# Altium schematic sheet Sheet3.SchDoc
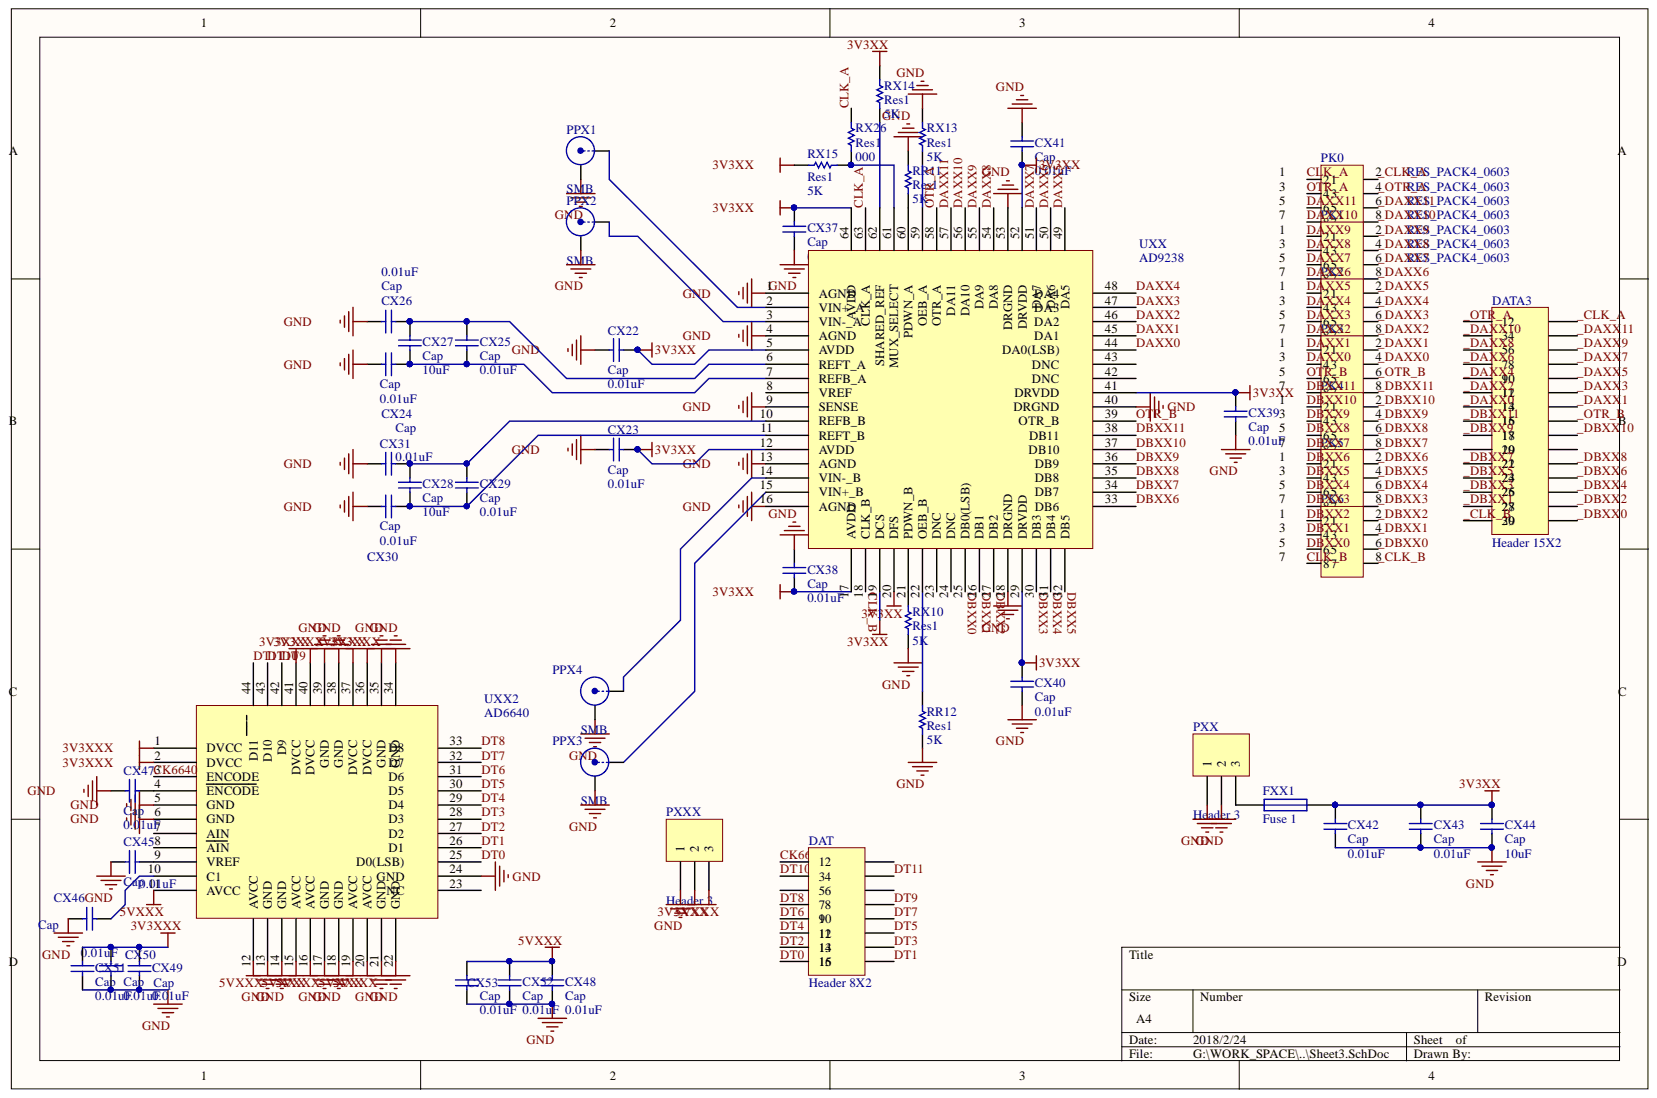
[diagram p0: Sheet3.SchDoc]

## Parts
CX22  Cap  value 0.01uF  [6-0805_N]
CX23  Cap  value 0.01uF  [6-0805_N]
CX24  Cap  value 0.01uF  [6-0805_N]
CX25  Cap  value 0.01uF  [6-0805_N]
CX26  Cap  value 0.01uF  [6-0805_N]
CX27  Cap  value 10uF  [C1206]
CX28  Cap  value 10uF  [C1206]
CX29  Cap  value 0.01uF  [6-0805_N]
CX30  Cap  value 0.01uF  [6-0805_N]
CX31  Cap  value 0.01uF  [6-0805_N]
CX37  Cap  value 0.01uF  [6-0805_N]
CX38  Cap  value 0.01uF  [6-0805_N]
CX39  Cap  value 0.01uF  [6-0805_N]
CX40  Cap  value 0.01uF  [6-0805_N]
CX41  Cap  value 0.01uF  [6-0805_N]
CX42  Cap  value 0.01uF  [6-0805_N]
CX43  Cap  value 0.01uF  [6-0805_N]
CX44  Cap  value 10uF  [C1206]
CX45  Cap  value 0.01uF  [6-0805_N]
CX46  Cap  value 0.01uF  [6-0805_N]
CX47  Cap  [6-0805_N]
CX48  Cap  value 0.01uF  [6-0805_N]
CX49  Cap  value 0.01uF  [6-0805_N]
CX50  Cap  value 0.01uF  [6-0805_N]
CX51  Cap  value 0.01uF  [6-0805_N]
CX52  Cap  value 0.01uF  [6-0805_N]
CX53  Cap  value 0.01uF  [6-0805_N]
DAT  Header 8X2  [HDR2X8]
DATA3  Header 15X2  [HDR2X15]
FXX1  Fuse 1  [6-0805_N]
PK0  RES_PACK4_0603  [8P4R_0603]
PK1  RES_PACK4_0603  [8P4R_0603]
PK2  RES_PACK4_0603  [8P4R_0603]
PK3  RES_PACK4_0603  [8P4R_0603]
PK4  RES_PACK4_0603  [8P4R_0603]
PK5  RES_PACK4_0603  [8P4R_0603]
PK6  RES_PACK4_0603  [8P4R_0603]
PPX1  SMB  [SMB_V-RJ45]
PPX2  SMB  [SMB_V-RJ45]
PPX3  SMB  [SMB_V-RJ45]
PPX4  SMB  [SMB_V-RJ45]
PXX  Header 3  [HDR1X3]
PXXX  Header 3  [HDR1X3]
RR11  Res1  value 5K  [6-0805_N]
RR12  Res1  value 5K  [6-0805_N]
RX10  Res1  value 5K  [6-0805_N]
RX13  Res1  value 5K  [6-0805_N]
RX14  Res1  value 5K  [6-0805_N]
RX15  Res1  value 5K  [6-0805_N]
RX26  Res1  value 000  [6-0805_N]
UXX  AD9238  [AD9238]
UXX2  AD6640  [TQFP80P1200X1200X160-44N]

## Nets
3V3XX: CX22.1 CX23.1 CX37.1 CX38.2 CX39.1 CX40.1 CX41.2 CX42.1 CX43.1 CX44.1 FXX1.1 RX14.2 RX15.2 UXX.5(AVDD) UXX.12(AVDD) UXX.17(AVDD) UXX.19(DCS) UXX.20(DFS) UXX.29(DRVDD) UXX.41 UXX.52 UXX.64
3V3XXX: CX49.2 CX50.2 CX51.2 PXXX.2 UXX2.1(DVCC) UXX2.2(DVCC) UXX2.36 UXX2.37 UXX2.40 UXX2.41
5VXXX: CX48.2 CX52.2 CX53.2 PXXX.3 UXX2.11(AVCC) UXX2.12(AVCC) UXX2.15(AVCC) UXX2.16(AVCC) UXX2.19(AVCC) UXX2.20(AVCC)
GND: CX22.2 CX23.2 CX24.2 CX26.2 CX30.1 CX31.1 CX37.2 CX38.1 CX39.2 CX40.2 CX41.1 CX42.2 CX43.2 CX44.2 CX45.1 CX46.1 CX47.1 CX48.1 CX49.1 CX50.1 CX51.1 CX52.1 CX53.1 PPX1.2 PPX2.2 PPX3.2 PPX4.2 PXX.1 PXX.2 PXXX.1 RR11.2 RR12.1 RX10.1 RX13.2 UXX.1(AGND) UXX.4(AGND) UXX.9(SENSE) UXX.13(AGND) UXX.16(AGND) UXX.28(DRGND) UXX.40 UXX.53 UXX2.5(GND) UXX2.6(GND) UXX2.13(GND) UXX2.14(GND) UXX2.17(GND) UXX2.18(GND) UXX2.21(GND) UXX2.22(GND) UXX2.24 UXX2.34 UXX2.35 UXX2.38 UXX2.39
_CLK_A: DATA3.2 PK0.2
_CLK_B: DATA3.29 PK6.8
_DAXX0: DATA3.13 PK3.4
_DAXX1: DATA3.14 PK3.2(DAXX1)
_DAXX2: DATA3.11 PK2.8
_DAXX3: DATA3.12 PK2.6
_DAXX4: DATA3.9 PK2.4
_DAXX5: DATA3.10 PK2.2
_DAXX6: DATA3.7 PK1.8(DAXX6)
_DAXX7: DATA3.8 PK1.6
_DAXX8: DATA3.5 PK1.4
_DAXX9: DATA3.6 PK1.2(DAXX9)
_DAXX10: DATA3.3 PK0.8
_DAXX11: DATA3.4 PK0.6(DAXX11)
_DBXX0: DATA3.30 PK6.6
_DBXX1: DATA3.27 PK6.4
_DBXX2: DATA3.28 PK6.2
_DBXX3: DATA3.25 PK5.8
_DBXX4: DATA3.26 PK5.6
_DBXX5: DATA3.23 PK5.4
_DBXX6: DATA3.24 PK5.2
_DBXX7: DATA3.21 PK4.8
_DBXX8: DATA3.22 PK4.6
_DBXX9: DATA3.17 PK4.4
_DBXX10: DATA3.18 PK4.2
_DBXX11: DATA3.15 PK3.8
_OTR_A: DATA3.1 PK0.4
_OTR_B: DATA3.16 PK3.6
CK6640: DAT.1 UXX2.3(ENCODE)
CLK_A: PK0.1(2_1) RX26.2 UXX.63
CLK_B: PK6.7(8_7) UXX.18(CLK_B)
DAXX0: PK3.3(4_3) UXX.44
DAXX1: PK3.1(2_1) UXX.45
DAXX2: PK2.7(8_7) UXX.46
DAXX3: PK2.5(6_5) UXX.47
DAXX4: PK2.3(4_3) UXX.48
DAXX5: PK2.1(2_1) UXX.49
DAXX6: PK1.7(PK2) UXX.50
DAXX7: PK1.5(6_5) UXX.51
DAXX8: PK1.3(4_3) UXX.54
DAXX9: PK1.1(2_1) UXX.55
DAXX10: PK0.7(8_7) UXX.56
DAXX11: PK0.5(6_5) UXX.57
DBXX0: PK6.5(6_5) UXX.25(DB0(LSB))
DBXX1: PK6.3(4_3) UXX.26(DB1)
DBXX2: PK6.1(2_1) UXX.27(DB2)
DBXX3: PK5.7(8_7) UXX.30(DB3)
DBXX4: PK5.5(6_5) UXX.31(DB4)
DBXX5: PK5.3(4_3) UXX.32(DB5)
DBXX6: PK5.1(2_1) UXX.33
DBXX7: PK4.7(8_7) UXX.34
DBXX8: PK4.5(6_5) UXX.35
DBXX9: PK4.3(4_3) UXX.36
DBXX10: PK4.1(2_1) UXX.37
DBXX11: PK3.7(8_7) UXX.38
DT0: DAT.15 UXX2.25
DT1: DAT.16 UXX2.26
DT2: DAT.13 UXX2.27
DT3: DAT.14 UXX2.28
DT4: DAT.11 UXX2.29
DT5: DAT.12 UXX2.30
DT6: DAT.9 UXX2.31
DT7: DAT.10 UXX2.32
DT8: DAT.7 UXX2.33
DT9: DAT.8 UXX2.42
DT10: DAT.3 UXX2.43
DT11: DAT.4 UXX2.44
OTR_A: PK0.3(4_3) UXX.58
OTR_B: PK3.5(6_5) UXX.39
NetCX24_1: CX24.1 CX25.2 CX27.2 UXX.7(REFB_A)
NetCX25_1: CX25.1 CX26.1 CX27.1 UXX.6(REFT_A)
NetCX28_1: CX28.1 CX29.1 CX31.2 UXX.10(REFB_B)
NetCX28_2: CX28.2 CX29.2 CX30.2 UXX.11(REFT_B)
NetCX45_2: CX45.2 UXX2.9(VREF)
NetCX46_2: CX46.2 UXX2.10(C1)
NetCX47_2: CX47.2 UXX2.4(ENCODE)
NetFXX1_2: FXX1.2 PXX.3
NetPPX1_1: PPX1.1 UXX.2(VIN+_A)
NetPPX2_1: PPX2.1 UXX.3(VIN-_A)
NetPPX3_1: PPX3.1 UXX.15(VIN+_B)
NetPPX4_1: PPX4.1 UXX.14(VIN-_B)
NetRR11_1: RR11.1 UXX.60
NetRR12_2: RR12.2 UXX.22(OEB_B)
NetRX10_2: RX10.2 UXX.21(PDWN_B)
NetRX13_1: RX13.1 UXX.59
NetRX14_1: RX14.1 UXX.62
NetRX15_1: RX15.1 RX26.1 UXX.61

Not connected: DAT 2 5 6; DATA3 19 20; UXX 8(VREF) 23(DNC) 24(DNC) 42 43; UXX2 7(AIN) 8(AIN) 23

## Library data of the parts
CX22-CX31 CX37-CX45 CX48-CX53 = Cap: Capacitor; Component Kind: Standard; LatestRevisionDate: 17-Jul-2002; LatestRevisionNote: Re-released for DXP Platform.; Library Name: Miscellaneous Devices.IntLib; PackageDescription: Radial Cap, Thru-Hole; 2 Leads; 0.3 in Pin Spacing; PackageReference: RAD-0.3; Published: 8-Jun-2000; Signal Integrity: Cap; Simulation: CAP; Publisher: Altium Limited
CX46 CX47 = Cap: Capacitor; Value: 0.01uF; Component Kind: Standard; LatestRevisionDate: 17-Jul-2002; LatestRevisionNote: Re-released for DXP Platform.; Library Name: Miscellaneous Devices.IntLib; PackageDescription: Radial Cap, Thru-Hole; 2 Leads; 0.3 in Pin Spacing; PackageReference: RAD-0.3; Published: 8-Jun-2000; Signal Integrity: Cap; Simulation: CAP; Publisher: Altium Limited
DAT = Header 8X2: Header, 8-Pin, Dual row; Component Kind: Standard; LatestRevisionDate: 17-Jul-2002; LatestRevisionNote: Re-released for DXP Platform.; Library Name: Miscellaneous Connectors.IntLib; Signal Integrity: Connector; Publisher: Altium Limited
DATA3 = Header 15X2: Header, 15-Pin, Dual row; Component Kind: Standard; LatestRevisionDate: 17-Jul-2002; LatestRevisionNote: Re-released for DXP Platform.; Library Name: Miscellaneous Connectors.IntLib; Signal Integrity: Connector; Publisher: Altium Limited
FXX1 = Fuse 1: Fuse; Component Kind: Standard; LatestRevisionDate: 17-Jul-2002; LatestRevisionNote: Re-released for DXP Platform.; Library Name: Miscellaneous Devices.IntLib; PackageDescription: Thru-Hole; 2 Leads; PackageReference: PIN-W2/E2.8; Published: 8-Jun-2000; Simulation: FUSE; Publisher: Altium Limited
PPX1-PPX4 = SMB: SMB Straight Connector; Component Kind: Standard; LatestRevisionDate: 17-Jul-2002; LatestRevisionNote: Re-released for DXP Platform.; Library Name: Miscellaneous Connectors.IntLib; Signal Integrity: Connector; Publisher: Altium Limited
PXX PXXX = Header 3: Header, 3-Pin; Component Kind: Standard; LatestRevisionDate: 17-Jul-2002; LatestRevisionNote: Re-released for DXP Platform.; Library Name: Miscellaneous Connectors.IntLib; Signal Integrity: Connector; Publisher: Altium Limited
RR11 RR12 RX10 RX13 RX14 RX26 = Res1: Resistor; Component Kind: Standard; LatestRevisionDate: 17-Jul-2002; LatestRevisionNote: Re-released for DXP Platform.; Library Name: Miscellaneous Devices.IntLib; PackageDescription: Axial Device, Thru-Hole; 2 Leads; 0.3 in Pin Spacing; PackageReference: AXIAL-0.3; Published: 8-Jun-2000; Signal Integrity: Res; Simulation: RESISTOR; Publisher: Altium Limited
RX15 = Res1: Resistor; Value: 5K; Component Kind: Standard; LatestRevisionDate: 17-Jul-2002; LatestRevisionNote: Re-released for DXP Platform.; Library Name: Miscellaneous Devices.IntLib; PackageDescription: Axial Device, Thru-Hole; 2 Leads; 0.3 in Pin Spacing; PackageReference: AXIAL-0.3; Published: 8-Jun-2000; Signal Integrity: Res; Simulation: RESISTOR; Publisher: Altium Limited
PK0-PK6 = RES_PACK4_0603: Component Kind: Standard; Library Name: RES_PACK4_0603.SchLib
UXX = AD9238: Component Kind: Standard; Library Name: AD9238.SchLib
UXX2 = AD6640: Component Kind: Standard; Library Name: AD6640.SchLib
